# Altium schematic sheet fonte.SchDoc(fonte)
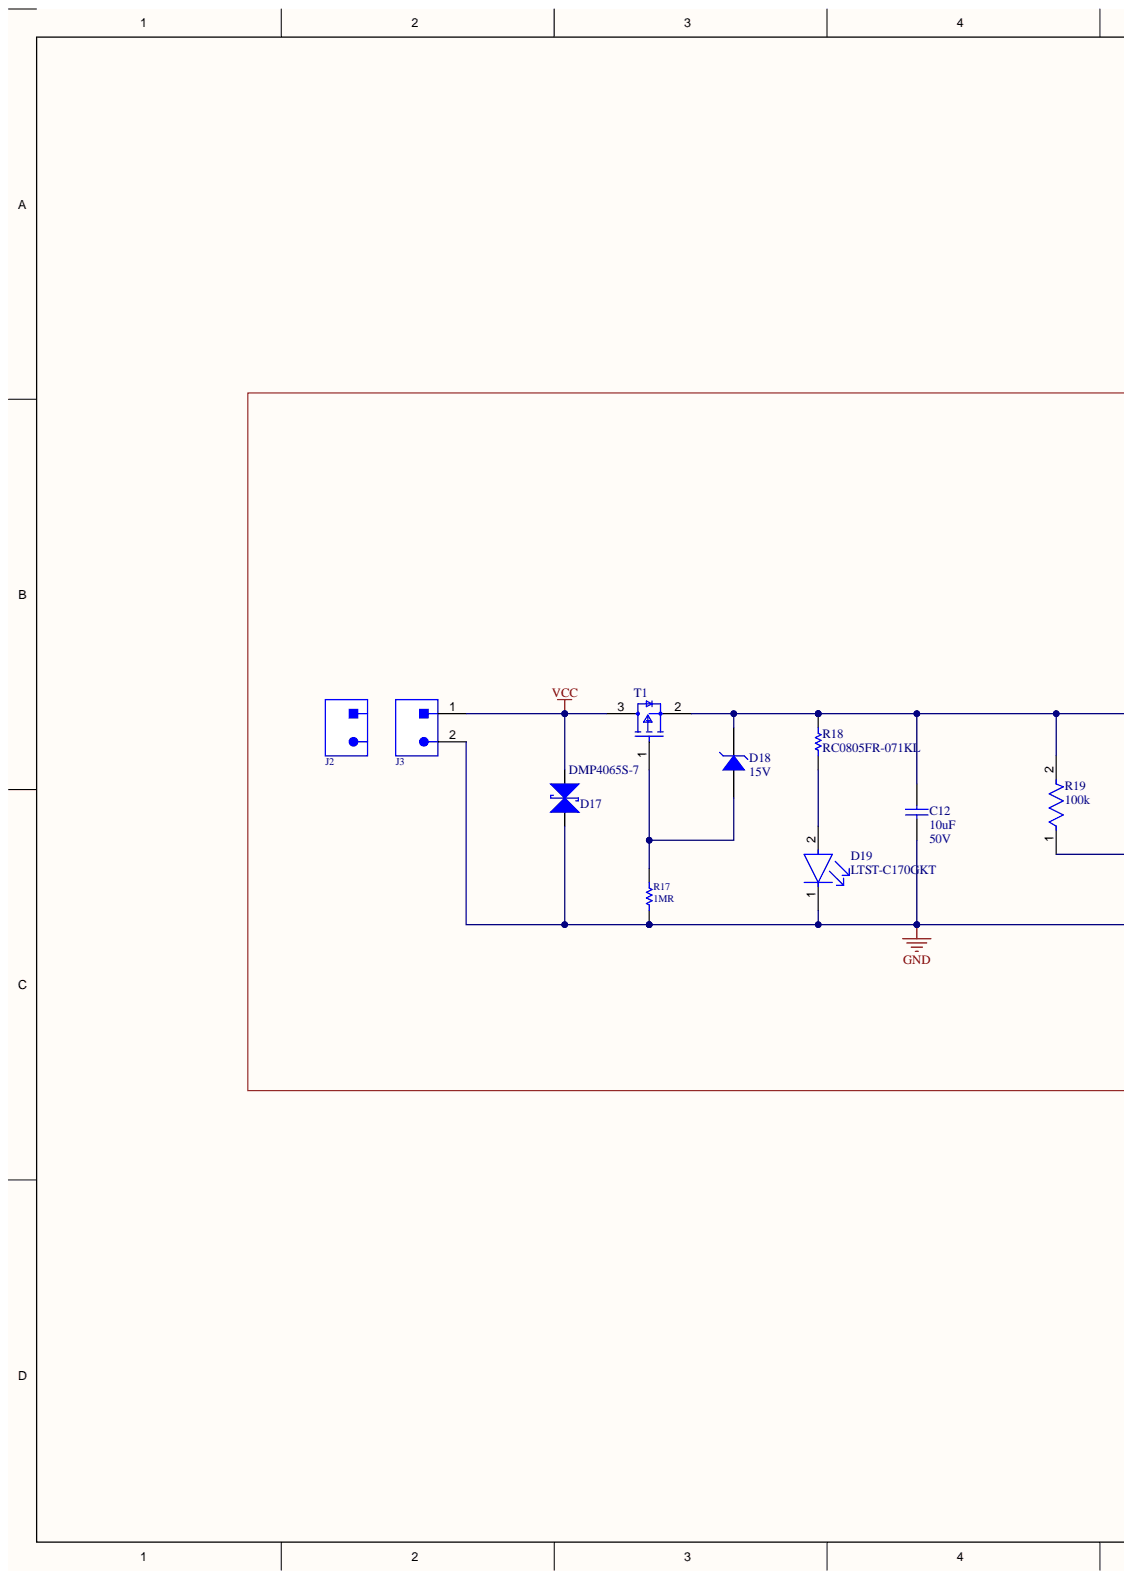
[diagram p0: fonte.SchDoc, left]
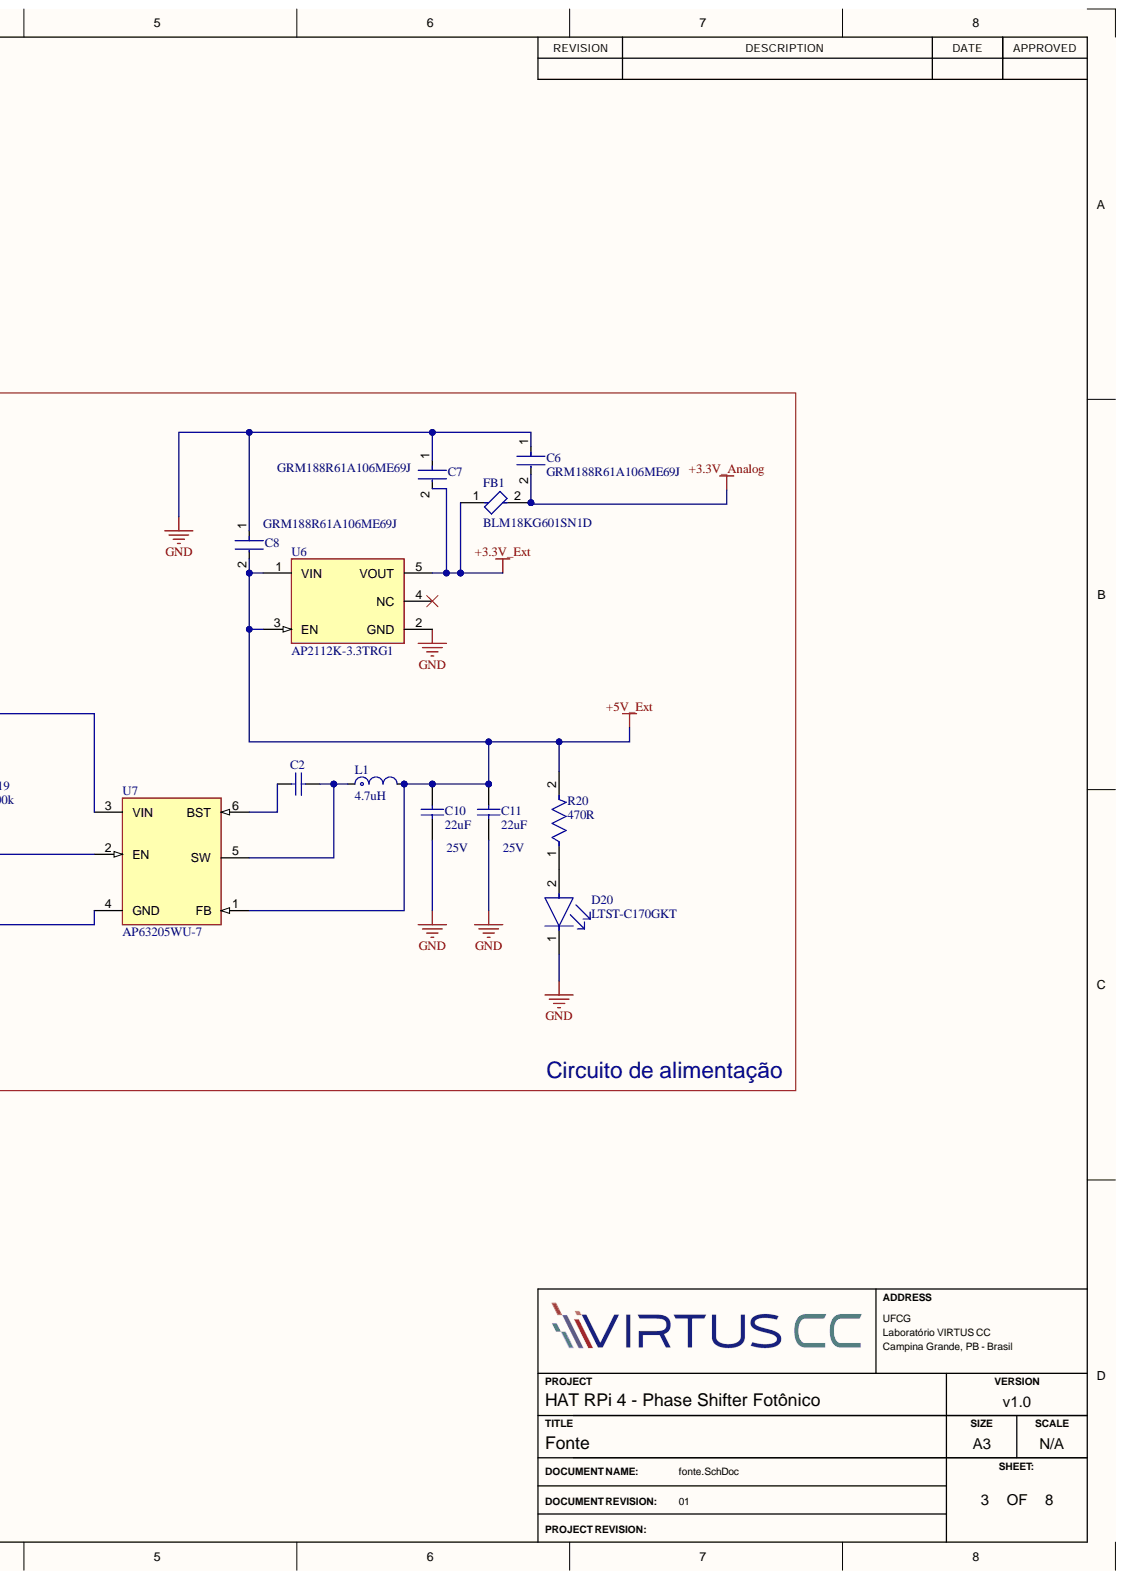
[diagram p1: fonte.SchDoc, right]

## Parts
C2  VJ0603Y104MXJCW1BC  [CAPC1608X95X40NL15T15]  (value not drawn)
C6  GRM188R61A106ME69J  [FP-GRM188-0_15-IPC_C]
C7  GRM188R61A106ME69J  [FP-GRM188-0_15-IPC_C]
C8  GRM188R61A106ME69J  [FP-GRM188-0_15-IPC_C]
C10  value 22uF  Voltage Rating: 25V  [C-0805]  (hidden comment: CL21A226MAYNNNE)
C11  value 22uF  Voltage Rating: 25V  [C-0805]  (hidden comment: CL21A226MAYNNNE)
C12  value 10uF  Voltage Rating: 50V  [C-1206]  (hidden comment: CL31A106KBHNNNE)
D17  SMBJ28CAQ-13-F  [DO-214AA]  (value not drawn)
D18  Zener Voltage: 15V  [SOD-123]  (hidden comment: MMSZ5245B-TP)
D19  LTST-C170GKT  [FP-LTST-C170GKT-MFG]
D20  LTST-C170GKT  [FP-LTST-C170GKT-MFG]
FB1  BLM18KG601SN1D  [FP-BLM18-0_15-t0_8-IPC_A]
J2  5447861  [5447861]  (value not drawn)
J3  5444262  [5444262]  (value not drawn)
L1  value 4.7uH  [FP-DG6045C-MFG]  (hidden comment: 1255AY-4R7M=P3)
R17  value 1MR  [R-0805]  (hidden comment: RC0805FR-071ML)
R18  RC0805FR-071KL  [RESC2013X60X35ML10T20]
R19  100k  [FP-CRGCQ0805-IPC_C]
R20  470R  [FP-CRGCQ0805-IPC_C]
T1  DMP4065S-7  [FP-SOT23-MFG]
U6  AP2112K-3.3TRG1  [FP-SOT25-IPC_A]
U7  AP63205WU-7  [TSOT-23-6_A]

## Nets
+3.3V_Analog: C6.2 FB1.2
+3.3V_Ext: C7.2 FB1.1 U6.5(VOUT)
+5V_Ext: C8.2 C10.1 C11.1 L1.2 R20.2 U6.1(VIN) U6.3(EN) U7.1(FB)
GND: C6.1 C7.1 C8.1 C10.2 C11.2 C12.2 D17.2 D19.1 D20.1 J3.2 R17.1 U6.2(GND) U7.4(GND)
VCC: D17.1 J3.1 T1.3
NetC2_1: C2.1 U7.6(BST)
NetC2_2: C2.2 L1.1 U7.5(SW)
NetC12_1: C12.1 D18.1 R18.2 R19.2 T1.2 U7.3(VIN)
NetD18_2: D18.2 R17.2 T1.1
NetD19_2: D19.2 R18.1
NetD20_2: D20.2 R20.1
NetR19_1: R19.1 U7.2(EN)

Not connected: U6 4(NC)

## Library data of the parts
C2 = CMP-2006-00058-1: Manufacturer: Vitramon; Component Kind: Standard; Library Name: Altium Content Vault; Part Number: VJ0603Y104MXJCW1BC
C6-C8 = CMP-06035-033844-1: Chip Multilayer Ceramic Capacitors for General Purpose, 0603, 10uF, X5R, 15%, 20%, 10V; Capacitance: 10uF; Component Kind: Standard; Dielectric: X5R; Length: 1.6mm; Library Name: Altium Content Vault; Thickness: 800um; Tolerance: 20%; Voltage Rating (DC): 10V; Width: 800um
C10 C11 = CL21A226MAYNNNE: CAP CER 22UF 25V X5R 0805; Manufacturer: Samsung; Material: 4241ConductorMaterial; Tolerance: 20%; Case/Package: 0805; Category: Ceramic Capacitors; Component Kind: Standard; ComponentLink1Description: https://datasheet.octopart.com/CL21A226MAYNNNE-Samsung-Electro-Mechanics-datasheet-149154243.pdf?src-supplier=Future+Electronics; ComponentLink1URL: https://datasheet.octopart.com/CL21A226MAYNNNE-Samsung-Electro-Mechanics-datasheet-149154243.pdf?src-supplier=Future+Electronics; ComponentLink2Description: https://datasheet.octopart.com/CL21A226MAYNNNE-Samsung-datasheet-8326407.pdf?src-supplier=TME; ComponentLink2URL: https://datasheet.octopart.com/CL21A226MAYNNNE-Samsung-datasheet-8326407.pdf?src-supplier=TME; Dielectric: X5R; Height: 1.45mm; Library Name: Schlib_Source_IoT.SchLib; Manufacturer Part Number: CL21A226MAYNNNE; Max Operating Temperature: 85°C; Min Operating Temperature: -55°C; Pricing: 1=0,11, 10=0,066, 50=0,044, 100=0,043 (USD); RoHS: Yes; RoHS Compliant: Yes; Stock: 120266; Supplier: Digikey; Supplier 1: Mouser; Supplier 2: Digikey; Supplier Part Number: 1276-CL21A226MAYNNNECT-ND; Supplier Part Number 1: 187-CL21A226MAYNNNE; Supplier Part Number 2: 1276-CL21A226MAYNNNECT-ND; Voltage Rating (DC): 25V
C12 = CL31A106KBHNNNE: CAP CER 10UF 50V X5R 1206; Manufacturer: Samsung; Material: Ceramic; Tolerance: 10%; Case Code (Imperial): 1206; Case Code (Metric): 3216; Case/Package: 1206; Category: Ceramic Capacitors; Component Kind: Standard; ComponentLink1Description: https://datasheet.ciiva.com/25726/cl31a106kbhnnne-25726404.pdf?src-supplier=Digikey; ComponentLink1URL: https://datasheet.ciiva.com/25726/cl31a106kbhnnne-25726404.pdf?src-supplier=Digikey; ComponentLink2Description: https://datasheet.ciiva.com/15216/getdatasheetpartid-353196-15216238.pdf?src-supplier=Verical; ComponentLink2URL: https://datasheet.ciiva.com/15216/getdatasheetpartid-353196-15216238.pdf?src-supplier=Verical; ComponentLink3Description: https://datasheet.ciiva.com/29201/452492-da-01-en-vs-kondensator-1206-29201107.pdf?src-supplier=Conrad; ComponentLink3URL: https://datasheet.ciiva.com/29201/452492-da-01-en-vs-kondensator-1206-29201107.pdf?src-supplier=Conrad; ComponentLink4Description: https://datasheet.ciiva.com/16437/cl05b104kq5nnnc-16437222.pdf?src-supplier=Future+Electronics; ComponentLink4URL: https://datasheet.ciiva.com/16437/cl05b104kq5nnnc-16437222.pdf?src-supplier=Future+Electronics; Construction: Flat; Depth: 1.6mm; Dielectric: X5R; Height: 1.6mm; Lead Free: Lead Free; Length: 3.2mm; Library Name: Schlib_Source_IoT.SchLib; Manufacturer Part Number: CL31A106KBHNNNE; Max Operating Temperature: 85°C; Min Operating Temperature: -55°C; Mounting Technology: Surface Mount; Package Quantity: 1; Packaging: Tape and Reel; Pricing: 1=0,11, 10=0,07 (USD); Radiation Hardening: No; RoHS: Yes; RoHS Compliant: Yes; Series: CL; Stock: 133875; Supplier: Digikey; Supplier 1: Mouser; Supplier 2: Digikey; Supplier Part Number: 1276-2876-1-ND; Supplier Part Number 1: 187-CL31A106KBHNNNE; Supplier Part Number 2: 1276-2876-2-ND; Thickness: 0.071inch; Voltage Rating (DC): 50V; Width: 0.063inch
D17 = SMBJ28CAQ-13-F: TVS DIODE 28VWM 45.4VC SMB; Manufacturer: Diodes; Clamping Voltage: 45.4V; Min Breakdown Voltage: 31.1V; Number of Bidirectional Channels: 1; Peak Pulse Current: 13.2A; Peak Pulse Power: 600W; Power Line Protection: No; Reverse Standoff Voltage: 28V; Case/Package: SMB; Category: TVS Diodes; Component Kind: Standard; ComponentLink1Description: https://www.diodes.com/assets/Datasheets/ds40740.pdf?src-supplier=Digi-Key; ComponentLink1URL: https://www.diodes.com/assets/Datasheets/ds40740.pdf?src-supplier=Digi-Key; Library Name: Schlib_Source_IoT.SchLib; Manufacturer Part Number: SMBJ28CAQ-13-F; Max Operating Temperature: 150°C; Min Operating Temperature: -55°C; Mount: Surface Mount; Packaging: Tape and Reel; Pricing 1: 1=0,44000, 10=0,37900, 100=0,28310, 500=0,22240, 1000=0,17185 (USD); Resistor Type: Zener; RoHS: Yes; RoHS Compliant: Yes; Stock 1: 16278; Supplier 1: Digi-Key; Supplier 2: Mouser; Supplier Part Number 1: SMBJ28CAQ-13-FDICT-ND; Supplier Part Number 2: 621-SMBJ28CAQ-13-F
D18 = MMSZ5245B-TP: DIODE ZENER 15V 500MW SOD123; Manufacturer: MCC; Element Configuration: Single; Impedance: 16R; Max Reverse Leakage Current: 100nA; Test Current: 8.5mA; Tolerance: 5%; Category: Zener Diodes; Component Kind: Standard; ComponentLink1Description: https://datasheet.ciiva.com/890/mmsz5221-mmsz5259sod123-890445.pdf?src-supplier=Digi-Key; ComponentLink1URL: https://datasheet.ciiva.com/890/mmsz5221-mmsz5259sod123-890445.pdf?src-supplier=Digi-Key; ComponentLink2Description: https://datasheet.ciiva.com/890/mmsz5221-mmsz5259sod123-890446.pdf?src-supplier=Digi-Key; ComponentLink2URL: https://datasheet.ciiva.com/890/mmsz5221-mmsz5259sod123-890446.pdf?src-supplier=Digi-Key; ComponentLink3Description: https://datasheet.ciiva.com/890/mmsz5221-mmsz5259sod123-890447.pdf?src-supplier=Digi-Key; ComponentLink3URL: https://datasheet.ciiva.com/890/mmsz5221-mmsz5259sod123-890447.pdf?src-supplier=Digi-Key; Lead Free: Lead Free; Library Name: Schlib_Source_IoT.SchLib; Manufacturer Part Number: MMSZ5245B-TP; Max Operating Temperature: 150°C; Max Power Dissipation: 500mW; Min Operating Temperature: -55°C; Mount: Surface Mount; Number of Pins: 2; Package Quantity: 1; Packaging: Tape and Reel; Power Dissipation: 500mW; Pricing 1: 1=0,22000, 10=0,17700, 100=0,09400, 500=0,06188, 1000=0,04208 (USD); RoHS: Yes; Series: -; Stock 1: 330368; Supplier 1: Digi-Key; Supplier 2: Mouser; Supplier 3: Arrow Electronics; Supplier Part Number 1: MMSZ5245B-TPMSCT-ND; Supplier Part Number 2: 833-MMSZ5245B-TP; Supplier Part Number 3: MMSZ5245B-TP; Voltage Tolerance: 5%
D19 D20 = CMP-1674-00002-6: LED GREEN CLEAR SMD; Dominant Wavelength: 569nm; Forward Current: 30mA; Forward Voltage: 2.1V; Lens Color: Colorless; Lens Style: Diffused; Luminous Intensity: 6mcd; Number of Elements: 1; Power Dissipation: 100mW; Reverse Voltage: 5V; Test Current: 10mA; Viewing Angle: 130°; Wavelength: 565nm; Case Code (Imperial): 0805; Case Code (Metric): 2012; Case/Package: 0805; Color: Green; Component Kind: Standard; Depth: 1.25mm; Height: 1.1mm; Illumination Color: Green; Lead Free: Lead Free; Length: 2mm; Lens Transparency: Clear; Library Name: Altium Content Vault; Max Operating Temperature: 85°C; Min Operating Temperature: -55°C; Mount: Surface Mount; Number of LEDs: 1; Number of Pins: 2; Package Quantity: 1; Packaging: Tape and Reel; Power Rating: 100mW; Radiation Hardening: No; Voltage: 5V; Width: 1.25mm
FB1 = CMP-0686-00581-3: Chip Ferrite Bead, 0603, 600Ω @ 100MHz, 0.15Ω, 25%, 1.3A; DC Resistance (DCR): 150mR; Impedance: 600R; Material: Steel; Number of Circuits: 1; Case Code (Imperial): 0603; Case Code (Metric): 1608; Case/Package: 0603; Component Kind: Standard; Core Material: Ferrite; Current Rating: 1.3A; DC Current: 1.3A; Depth: 0.8mm; Height: 0.8mm; Inductor Type: FERRITE BEAD; Lead Free: Lead Free; Length: 1.6mm; Library Name: Altium Content Vault; Max Current Rating: 1.3A; Max DC Current: 1.3A; Max Operating Temperature: 125°C; Military Standard: Not; Min Operating Temperature: -55°C; Number of Lines: 1; Number of Pins: 2; Package Quantity: 1; Packaging: Tape and Reel; Radiation Hardening: No; REACH SVHC: No SVHC; RoHS Compliant: Yes; Series: BLM18K; Termination: SMD/SMT; Test Frequency: 100MHz; Tolerance: 25%; Weight: 7.1E-05oz; Width: 0.8mm
J2 = 5447861: TERM BLOCK PLUG 2POS STR 3.81MM; Manufacturer: Phoenix Contact; Connector Type: Screw; Current: 8A; Number of Levels: 1; Number of Positions: 2; Pitch: 0.15inch; Voltage: 300V; Voltage Rating: 160V; Wire Gauge (Max): 30AWG; Wire Gauge (Min): 14AWG; Category: Terminal Blocks; Color: Green; Component Kind: Standard; ComponentLink1Description: https://datasheet.ciiva.com/29706/5447861-29706032.pdf?src-supplier=Digi-Key; ComponentLink1URL: https://datasheet.ciiva.com/29706/5447861-29706032.pdf?src-supplier=Digi-Key; Contact Plating: Tin; Current Rating: 8A; Flammability Rating: UL94 V-0; Housing Material: Polyamide; Library Name: Schlib_Source_IoT.SchLib; Manufacturer Part Number: 5447861; Mount: Free Hanging; Number of Pins: 2; Number of Positions Per Level: 2; Package Quantity: 100; Packaging: Bulk; Pricing 1: 1=2,56000, 10=2,45300, 50=2,38620, 100=2,23000, 250=2,05160, 500=1,89550, 1000=1,80631, 2500=1,77954 (USD); RoHS: Yes; Stock 1: 30203; Supplier 1: Digi-Key; Supplier 2: Mouser; Supplier Part Number 1: 277-11343-ND; Supplier Part Number 2: 651-5447861; Termination: Screw
J3 = 5444262: TERM BLOCK HDR 2POS 90DEG 3.81MM; Manufacturer: Phoenix Contact; Current: 8A; Number of Levels: 1; Number of Positions: 2; Pitch: 0.15inch; Voltage: 300V; Voltage Rating: 160V; Category: Terminal Blocks; Color: Green; Component Kind: Standard; ComponentLink1Description: https://datasheet.ciiva.com/29682/5444262-29682658.pdf?src-supplier=Digi-Key; ComponentLink1URL: https://datasheet.ciiva.com/29682/5444262-29682658.pdf?src-supplier=Digi-Key; Contact Plating: Tin; Current Rating: 8A; Flammability Rating: UL94 V-0; Housing Material: Polyamide; Length: 9.2mm; Library Name: Schlib_Source_IoT.SchLib; Manufacturer Part Number: 5444262; Mount: Through Hole; Number of Positions Per Level: 2; Package Quantity: 100; Packaging: Bulk; Pricing 1: 1=0,87000, 10=0,83600, 50=0,81320, 100=0,76000, 250=0,69920, 500=0,64600, 1000=0,61560, 2500=0,60648 (USD); RoHS: Yes; RoHS Compliant: Yes; Stock 1: 66186; Supplier 1: Digi-Key; Supplier 2: Mouser; Supplier Part Number 1: 277-11313-ND; Supplier Part Number 2: 651-5444262; Termination: Solder
L1 = 1255AY-4R7M=P3: FIXED IND 4.7UH 4A 23 MOHM SMD; Manufacturer: Murata; Core Material: Ferrite; Current Rating: 4.5A; DC Resistance (DCR): 27.6mR; Inductance Tolerance: 20%; Shielding: Shielded; Test Frequency: 100kHz; Case/Package: Nonstandard; Category: Fixed Inductors; Component Kind: Standard; ComponentLink1Description: http://search.murata.co.jp/Ceramy/image/img/P02/J(E)TE243B-0037_DG6045C_reference.pdf?src-supplier=Digikey; ComponentLink1URL: http://search.murata.co.jp/Ceramy/image/img/P02/J(E)TE243B-0037_DG6045C_reference.pdf?src-supplier=Digikey; ComponentLink2Description: http://www.toko.co.jp/products/pdf/inductors/dg6045c.pdf?src-supplier=Digikey; ComponentLink2URL: http://www.toko.co.jp/products/pdf/inductors/dg6045c.pdf?src-supplier=Digikey; ComponentLink3Description: http://www.toko.co.jp/products/pdf/inductors/dg6045c.pdf?src-supplier=Avnet; ComponentLink3URL: http://www.toko.co.jp/products/pdf/inductors/dg6045c.pdf?src-supplier=Avnet; ESR: 27.6mR; Height: 4.5mm; Height - Seated (Max): 0.177inch; Length: 0.248inch; Library Name: Schlib_Source_IoT.SchLib; Manufacturer Part Number: 1255AY-4R7M=P3; Max Operating Temperature: 85°C; Min Operating Temperature: -40°C; Mounting Technology: Surface Mount; Packaging: Cut Tape; Pricing: 1=0,38, 10=0,314, 100=0,2584 (USD); RMS Current (Irms): 4A; RoHS: Yes; RoHS Compliant: Yes; Saturation Current: 4.5A; Series: DG6045C; Stock: 84053; Supplier: Digikey; Supplier Part Number: 490-10812-1-ND; Tolerance: 20%; Width: 0.248inch
R17 = RC0805FR-071ML: RES 1M OHM 1% 1/8W 0805; Manufacturer: Yageo; Power Rating: 125mW; Temperature Coefficient: 250ppm/°C; Voltage Rating: 150V; Case Code (Imperial): 0805; Case Code (Metric): 2012; Case/Package: Molded; Category: Chip SMD Resistors; Component Kind: Standard; ComponentLink1Description: https://datasheet.ciiva.com/17419/rc0805-17419909.pdf?src-supplier=Future+Electronics; ComponentLink1URL: https://datasheet.ciiva.com/17419/rc0805-17419909.pdf?src-supplier=Future+Electronics; Construction: Rectangular; Depth: 1.25mm; Lead Free: Lead Free; Length: 2mm; Library Name: Schlib_Source_IoT.SchLib; Manufacturer Part Number: RC0805FR-071ML; Max Operating Temperature: 155°C; Military Standard: Not; Min Operating Temperature: -55°C; Packaging: Tape and Reel; Pricing: 1=0,1, 10=0,026, 50=0,0162, 100=0,0134, 500=0,00906, 1000=0,00778 (USD); Reach SVHC Compliant: Unknown; Resistance Tolerance: 1%; Resistor Type: Thick Film; RoHS: Yes; RoHS Compliant: Yes; Stock: 145027; Supplier: Digikey; Supplier 1: Digikey; Supplier 2: Mouser; Supplier Part Number: 311-1.00MCRCT-ND; Supplier Part Number 1: 311-1.00MCRCT-ND; Supplier Part Number 2: 603-RC0805FR-071ML; Tolerance: 1%; Voltage Rating (AC): 150V
R18 = CMP-2100-03671-1: Chip Resistor, 1 KOhm, +/- 1%, 125 mW, -55 to 155 degC, 0805 (2012 Metric), RoHS, Tape and Reel; Manufacturer: Yageo; Max Power Dissipation: 125mW; Resistance: 1KOhm; Temperature Coefficient: 100ppm/degreesC; Voltage Rating: 150V; Case Code (Imperial): 0805; Case Code (Metric): 2012; Case/Package: 0805; Component Kind: Standard; Composition: ThickFilm; Datasheet URL: http://www.yageo.com/NewPortal/yageodocoutput?fileName=/pdf/R-Chip/PYu-RC_Group_51_RoHS_L_5.pdf; Datasheet Version: Rev. 5, 10/2016; Depth: 1.25mm; Height: 0.024inch; Lead Free: LeadFree; Length: 0.079inch; Library Name: Altium Content Vault; Manufacturer URL: http://www.yageo.com/; Max Operating Temperature: 155degC; Military Standard: Not; Min Operating Temperature: -55degC; Number of Pins: 2; Number of Terminations: 2; Package Description: 2-Pin Surface Mounted Device, Body 2 x 1.25 mm; Package Quantity: 1; Package Reference: 0805; Packaging: TapeandReel; Power Rating: 125mW; REACH SVHC: Unknown; RoHSCompliant: true; Termination: SMD/SMT; Tolerance: 1%; Voltage Rating (DC): 150V; Width: 0.049inch
R19 = CMP-03211-000816-1: CRGCQ 0805 100K 1%; Manufacturer: TE Connectivity; Manufacturer Part Number: CRGCQ0805F100K; Power Rating: 0.125W; Resistance: 100KΩ; Temperature Coefficient: ±100ppm/°C; Tolerance: 0.01; Value: 100KΩ; Voltage Rating: 150V; Ambient Temperature Range High: +70°C; Automotive: Yes; Automotive Grade: Grade 1141; Category: Res; Component Kind: Standard; ComponentLink2Description: Datasheet; ComponentLink2URL: https://www.te.com/commerce/DocumentDelivery/DDEController?Action=showdoc&DocId=Data+Sheet%7F1773204-3%7F1%7Fpdf%7FEnglish%7FENG_DS_1773204-3_1.pdf%7F4-2176341-1150; Composition: Thick Film; Device Class L1: Passive Components; Device Class L2: Resistors; Device Class L3: Chip SMD Resistors; Digikey Description: CRGCQ 0805 100K 1%; Height: 0.65mm; Ipc Land Pattern Name: RESC200125X55; Lead Free: Yes; Library Name: Altium Content Vault; Mouser Description: Res Thick Film 0805 100K Ohm 1% 0.125W(1/8W) ±100ppm/C Pad SMD Automotive T/R; Octopart: https://octopart.com/crgcq0805f100k-te+connectivity-91018138; Package: CRGCQ0805; RoHS: TRUE; Series: CRGCQ; Temperature: -55°C to +155°C; Temperature Range High: +155°C; Temperature Range Low: -55°C
R20 = CMP-03211-002267-1: CRGCQ0805 470Ω 0.125W ±100ppm/°C 5% 150V; Manufacturer: TE Connectivity; Manufacturer Part Number: CRGCQ0805J470R; Automotive Grade: Grade 610; Tolerance: 0.05; Ambient Temperature Range High: +70°C; Automotive: Yes; Category: Res; Component Kind: Standard; ComponentLink2Description: Datasheet; ComponentLink2URL: https://www.te.com/commerce/DocumentDelivery/DDEController?Action=showdoc&DocId=Data+Sheet%7F1773204-3%7F1%7Fpdf%7FEnglish%7FENG_DS_1773204-3_1.pdf%7F4-2176341-619; Composition: Thick Film; Device Class L1: Passive Components; Device Class L2: Resistors; Device Class L3: Chip SMD Resistors; Height: 0.65mm; Ipc Land Pattern Name: RESC200125X55; Lead Free: Yes; Library Name: Altium Content Vault; Octopart: https://octopart.com/crgcq0805j470r-te+connectivity-91018229; Package: CRGCQ0805; Power Rating: 0.125W; Resistance: 470Ω; RoHS: TRUE; Series: CRGCQ; Temperature: -55°C to +155°C; Temperature Coefficient: ±100ppm/°C; Temperature Range High: +155°C; Temperature Range Low: -55°C; Value: 470Ω; Voltage Rating: 150V
T1 = CMP-12801-000050-1: MOSFET P-CH 40V 2.4A SOT23; Manufacturer: Diodes Inc.; Continuous Drain Current: -2.4A; Depletion Mode: False; Drain To Source Breakdown Voltage: -40V; Drain To Source Resistance: 64mΩ; Gate Charge At Vgs: 12.2nC @ -10V; Gate To Source Voltage: 20V; Input Capacitace At Vds: 587pF @ -20V; Max Forward Diode Voltage: -1.2V; Max Junction Temp: +150°C; Number Of Channels: 1; Power Dissipation: 0.72W; Pulse Drain Current: -20A; Rthja Max: 171°C/W; Standoff Height: 0.013mm; Threshold Vgs Max: -3V; Threshold Vgs Min: -1V; Turn Off Delay Time: 36.3ns; Turn On Delay Time: 3.6ns; Typ Forward Diode Voltage: -0.7V; Automotive: No; Category: Trans; Component Kind: Standard; ComponentLink2Description: Datasheet; ComponentLink2URL: https://www.diodes.com/assets/Datasheets/DMP4065S.pdf; Device Class L1: Discrete Semiconductors; Device Class L2: Transistors; Device Class L3: MOSFETs; Digikey Description: MOSFET P-CH 40V 2.4A SOT23; Drain To Source Voltage: -40V; Footprint Url: https://www.diodes.com/assets/Package-Files/SOT23.pdf; Height: 1.1mm; Ipc Land Pattern Name: SOT92P240X102-3; Lead Free: Yes; Library Name: Altium Content Vault; Manufacturer Part Number: DMP4065S-7; Mouser Description: Trans MOSFET P-CH 40V 2.4A Automotive 3-Pin SOT-23 T/R; Number Of P Channels: 1; Octopart: https://octopart.com/dmp4065s-7-diodes+inc.-57605044; Package: SOT23; RoHS: TRUE; Temperature: -55°C to +150°C; Temperature Range High: +150°C; Temperature Range Low: -55°C
U6 = CMP-12756-000035-1: IC REG LINEAR 3.3V 600MA SOT25; Accuracy: 1.5%; Dropout Voltage: 250mV; Max Input Voltage: 6V; Min Input Voltage: 2.5V; Number of Outputs: 1; Output Type: Fixed; Polarity: Positive; Quiescent Current: 55uA; Case/Package: SOT-23-5; Component Kind: Standard; Height: 1.3mm; Lead Free: Lead Free; Length: 3.1mm; Library Name: Altium Content Vault; Max Operating Temperature: 85°C; Max Output Current: 600mA; Min Operating Temperature: -40°C; Mount: Surface Mount; Number of Pins: 5; Number of Regulators: 1; Output Current: 600mA; Output Voltage: 3.3V; Package Quantity: 1; Packaging: Tape and Reel; Width: 1.7mm
U7 = AP63205WU-7: IC REG BUCK 5V 2A TSOT23-6; Manufacturer: Diodes; Max Duty Cycle: 100%; Max Supply Voltage: 32V; Min Supply Voltage: 3.8V; Nominal Supply Current: 22uA; Number of Outputs: 1; Switching Frequency: 1.1MHz; Category: Voltage Regulators - Switching; Component Kind: Standard; ComponentLink1Description: https://www.diodes.com/assets/Datasheets/AP63200-AP63201-AP63203-AP63205.pdf?src-supplier=Digikey; ComponentLink1URL: https://www.diodes.com/assets/Datasheets/AP63200-AP63201-AP63203-AP63205.pdf?src-supplier=Digikey; Height: 1mm; Library Name: Schlib_Source_IoT.SchLib; Manufacturer Part Number: AP63205WU-7; Max Operating Temperature: 85°C; Min Operating Temperature: -40°C; Output Current: 2A; Output Voltage: 5V; Pricing: 1=0,71, 10=0,506, 25=0,4548, 100=0,398, 250=0,37088, 500=0,35456, 1000=0,34112 (USD); RoHS: Yes; Stock: 6143; Supplier: Digikey; Supplier 1: Digikey; Supplier 2: Mouser; Supplier Part Number: AP63205WU-7DICT-ND; Supplier Part Number 1: AP63205WU-7DICT-ND; Supplier Part Number 2: 621-AP63205WU-7
